# Altium schematic sheet Motor_Controller.SchDoc
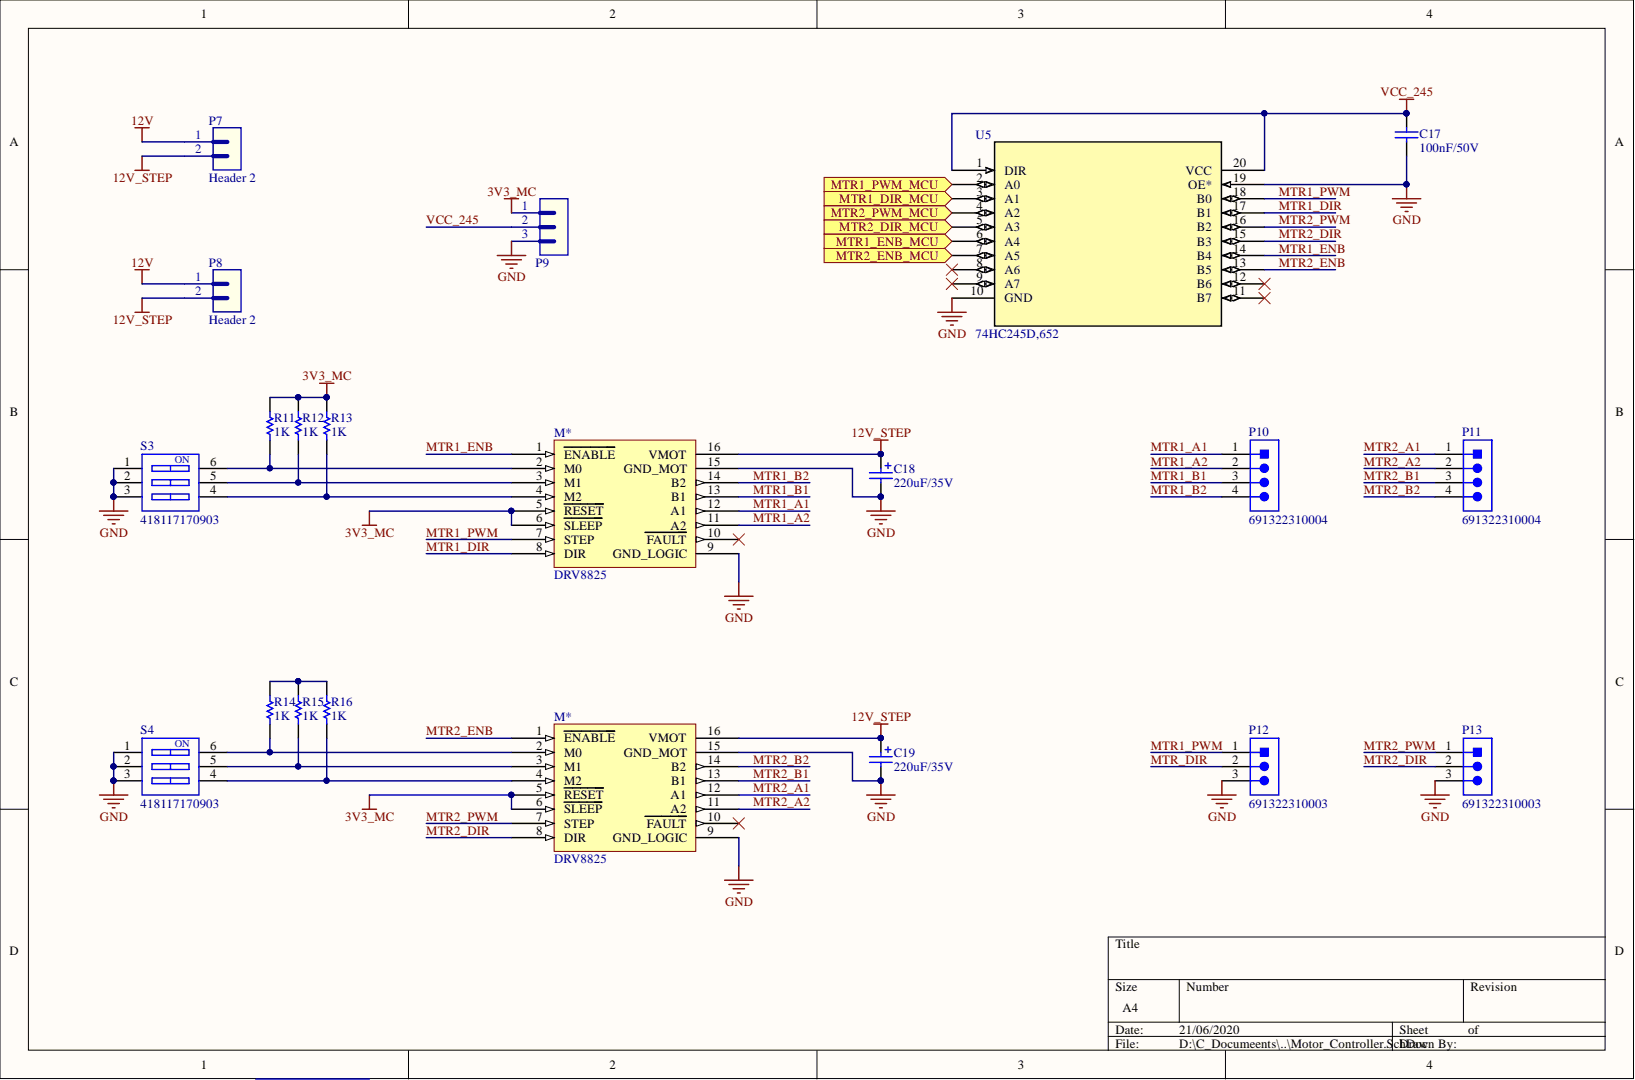
[diagram p0: Motor_Controller.SchDoc]

## Parts
C17  value 100nF/50V  [CAP-SM-0805]  (hidden comment: Cap-100nF-50V-0805)
C18  value 220uF/35V  [CAP-SM-AL-SIZE-F-D8L10]  (hidden comment: Cap-Al-220uF-35V-D8L10)
C19  value 220uF/35V  [CAP-SM-AL-SIZE-F-D8L10]  (hidden comment: Cap-Al-220uF-35V-D8L10)
M*  DRV8825  [IC_DRV8825_STEPPER_MOTOR_DRIVER_CARRIER]
M*  DRV8825  [IC_DRV8825_STEPPER_MOTOR_DRIVER_CARRIER]
P7  Header 2  [JP2]
P8  Header 2  [JP2]
P9  Header 3  [JP3]  (value not drawn)
P10  691322310004  [691322310004]
P11  691322310004  [691322310004]
P12  691322310003  [691322310003]
P13  691322310003  [691322310003]
R11  value 1K  [RES-SM-0805]  (hidden comment: Res-1K-0805)
R12  value 1K  [RES-SM-0805]  (hidden comment: Res-1K-0805)
R13  value 1K  [RES-SM-0805]  (hidden comment: Res-1K-0805)
R14  value 1K  [RES-SM-0805]  (hidden comment: Res-1K-0805)
R15  value 1K  [RES-SM-0805]  (hidden comment: Res-1K-0805)
R16  value 1K  [RES-SM-0805]  (hidden comment: Res-1K-0805)
S3  418117170903  [418117170903]
S4  418117170903  [418117170903]
U5  74HC245D,652  [SOT163-1_NEX]

## Ports
MTR1_DIR_MCU, MTR1_ENB_MCU, MTR1_PWM_MCU, MTR2_DIR_MCU, MTR2_ENB_MCU, MTR2_PWM_MCU

## Nets
3V3_MC: M*.5 M*.6 P9.1 R11.1 R12.1 R13.1
12V: P7.1 P8.1
12V_STEP: C18.1 C19.1 M*.16 P7.2 P8.2
GND: C17.1 C18.2 C19.2 M*.9 M*.15 P9.3 P12.3 P13.3 S3.1 S3.2 S3.3 S4.1 S4.2 S4.3 U5.10(GND) U5.19(OE*)
MTR1_A1: M*.12 P10.1
MTR1_A2: M*.11 P10.2
MTR1_B1: M*.13 P10.3
MTR1_B2: M*.14 P10.4
MTR1_DIR: M*.8 U5.17(B1)
MTR1_DIR_MCU: U5.3(A1) ; port MTR1_DIR_MCU
MTR1_ENB: M*.1 U5.14(B4)
MTR1_ENB_MCU: U5.6(A4) ; port MTR1_ENB_MCU
MTR1_PWM: M*.7 P12.1 U5.18(B0)
MTR1_PWM_MCU: U5.2(A0) ; port MTR1_PWM_MCU
MTR2_A1: M*.12 P11.1
MTR2_A2: M*.11 P11.2
MTR2_B1: M*.13 P11.3
MTR2_B2: M*.14 P11.4
MTR2_DIR: M*.8 P13.2 U5.15(B3)
MTR2_DIR_MCU: U5.5(A3) ; port MTR2_DIR_MCU
MTR2_ENB: M*.1 U5.13(B5)
MTR2_ENB_MCU: U5.7(A5) ; port MTR2_ENB_MCU
MTR2_PWM: M*.7 P13.1 U5.16(B2)
MTR2_PWM_MCU: U5.4(A2) ; port MTR2_PWM_MCU
MTR_DIR: P12.2
VCC_245: C17.2 P9.2 U5.1(DIR) U5.20(VCC)
NetM*_2: M*.2 R11.2 R14.2 S3.6 S4.6
NetM*_3: M*.3 R12.2 R15.2 S3.5 S4.5
NetM*_4: M*.4 R13.2 R16.2 S3.4 S4.4
NetR14_1: R14.1 R15.1 R16.1
N00130:

Not connected: M* 10; U5 8(A6) 9(A7) 11(B7) 12(B6)

## Library data of the parts
C17 = Cap-100nF-50V-0805: CAP CER 0.1UF 50V Y5V 0805; Manufacturer: Samsung Electro-Mechanics; Manufacturer Part Number: CL21F104ZBCNNNC; Component Kind: Standard; Library Name: Capacitor.SchLib; Local Supplier: thegioiic; Local Supplier Link: https://thegioiic.com/products/tu-100nf-0-1uf-50v-0805; Supplier 1: Digikey; Supplier 1 Link: https://www.digikey.com/product-detail/en/samsung-electro-mechanics/CL21F104ZBCNNNC/1276-1007-1-ND/3889093; Supplier 1 Part Number: 1276-1007-1-ND
C18 C19 = Cap-Al-220uF-35V-D8L10: CAP ALUM 220UF 20% 35V SMD; Manufacturer: Nichicon; Manufacturer Part Number: UWT1V221MNL1GS; Component Kind: Standard; Library Name: Capacitor.SchLib; Local Supplier: thegioiic; Local Supplier Link: https://thegioiic.com/products/tu-nhom-smd-220uf-35v-d8l10; Supplier 1: Digikey; Supplier 1 Link: https://www.digikey.com/product-detail/en/nichicon/UWT1V221MNL1GS/493-2205-2-ND/589946; Supplier 1 Part Number: 493-2205-2-ND
P7 P8 = Header 2: Header, 2-Pin; Component Kind: Standard; LatestRevisionDate: 17-Jul-2002; LatestRevisionNote: Re-released for DXP Platform.; Library Name: Header-connector.SchLib; Publisher: Altium Limited
P9 = Header 3: Header, 3-Pin; Component Kind: Standard; LatestRevisionDate: 17-Jul-2002; LatestRevisionNote: Re-released for DXP Platform.; Library Name: Header-connector.SchLib; Publisher: Altium Limited
P10 P11 = 691322310004: WR-TBL Serie 322 Horizontal PCB Header, Pluggable, pitch 3.81mm, 4p; Compliance: RoHS; Modularity: No; Pin Number: 4; Rated Current [UL]: 10A; Working Voltage [UL]: 300VAC; Application: Pluggable; Component Kind: Standard; ComponentLink1Description: Manufacturer; ComponentLink1URL: http://www.we-online.de; ComponentLink2Description: Datasheet; ComponentLink2URL: https://katalog.we-online.de/em/datasheet/691322310004.pdf; Mounting Technology: THT; Operating Temperature: -40 to +105degC; Packaging: Box; Part Number: 691322310004; PCB/Cable/Panel: PCB; Pitch: 3.81mm; Published Date: October 2019; Type: Horizontal; Library Name: Domino_691111710003_Altium_WR-TBL_rev19a.IntLib; Publisher: Wurth Elektronik
P12 P13 = 691322310003: WR-TBL Serie 322 Horizontal PCB Header, Pluggable, pitch 3.81mm, 3p; Compliance: RoHS; Modularity: No; Pin Number: 3; Rated Current [UL]: 10A; Working Voltage [UL]: 300VAC; Application: Pluggable; Component Kind: Standard; ComponentLink1Description: Manufacturer; ComponentLink1URL: http://www.we-online.de; ComponentLink2Description: Datasheet; ComponentLink2URL: https://katalog.we-online.de/em/datasheet/691322310003.pdf; Mounting Technology: THT; Operating Temperature: -40 to +105degC; Packaging: Box; Part Number: 691322310003; PCB/Cable/Panel: PCB; Pitch: 3.81mm; Published Date: October 2019; Type: Horizontal; Library Name: Domino_691111710003_Altium_WR-TBL_rev19a.IntLib; Publisher: Wurth Elektronik
R11-R16 = Res-1K-0805: RES 1K OHM 1% 1/4W 0805; Manufacturer: Stackpole Electronics Inc; Manufacturer Part Number: RNCP0805FTD1K00; Component Kind: Standard; Library Name: Resistor.SchLib; Local Supplier: thegioiic; Local Supplier Link: https://thegioiic.com/products/dien-tro-1k-ohm-0805-5; Supplier 1: Digikey; Supplier 1 Link: https://www.digikey.com/product-detail/en/stackpole-electronics-inc/RNCP0805FTD1K00/RNCP0805FTD1K00CT-ND/2240568; Supplier 1 Part Number: RNCP0805FTD1K00CT-ND
S3 S4 = 418117170903: WS-DITV Compact THT Dip Switch, Flat Actuator, pitch 2.54mm, 3p; Actuator Type: Flat Type; Circuit: SPST-NO; Operation Force: 800g; Pole Number: 3; Rated Current Non-Switching: 100mA; Rated Current Switching: 25mA; Rated Voltage Non-Switching: 50VDC; Rated Voltage Switching: 24VDC; Component Kind: Standard; ComponentLink1Description: Manufacturer; ComponentLink1URL: http://www.we-online.de; ComponentLink2Description: Datasheet; ComponentLink2URL: https://katalog.we-online.com/em/datasheet/418117170903.pdf; Contact Material: Copper Alloy; Contact Plating: Gold; Library Name: 418117170903_Altium_WS-DITV_rev19a.IntLib; Mounting Technology: THT; Operating Temperature: -40 to +85degC; Packaging: Tube; Part Number: 418117170903; Pitch: 2.54mm; Published Date: September 2019; Publisher: Wurth Elektronik
U5 = 74HC245D,652: No Description Available; SourceLibrary: Nexperia_2018-05-2018; Vendor: Nexperia; Component Kind: Standard; Library Name: 74HC245D_652_2020-06-19_16-38-41.SchLib; Manufacturer_Part_Number: 74HC245D,652; RefDes: RefDes; Type: DEV; Copyright: Copyright (C) 2016 Accelerated Designs. All rights reserved
M* M* = DRV8825: Component Kind: Standard; Library Name: Project_Xe_Can_Bang.SchLib
